# Altium schematic sheet MECHANICAL.SchDoc(MECHANICAL)
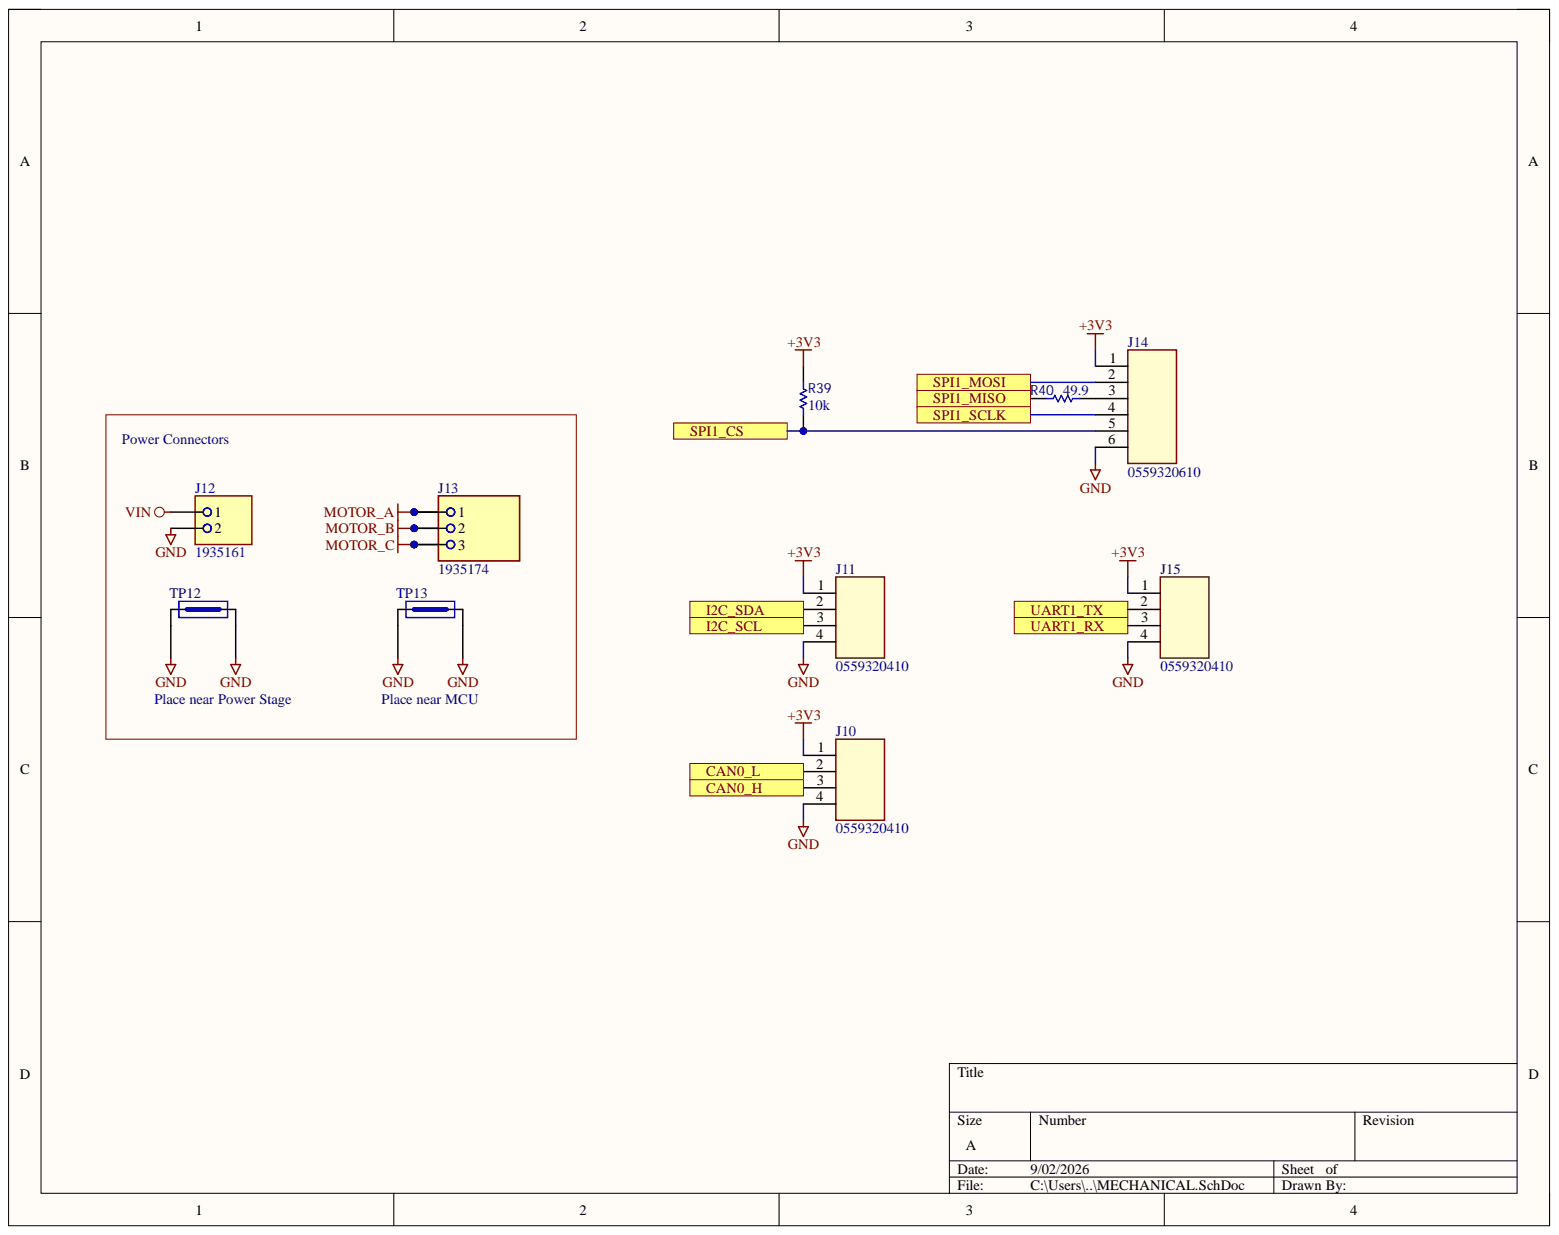
[diagram p0: MECHANICAL.SchDoc]

## Parts
J10  0559320410  [CONN_SD-55932-002_04_MOL]
J11  0559320410  [CONN_SD-55932-002_04_MOL]
J12  1935161  [PHOENIX_1935161]
J13  1935174  [PHOENIX_1935174]
J14  0559320610  [CONN_SD-55932-002_06_MOL]
J15  0559320410  [CONN_SD-55932-002_04_MOL]
R39  value 10k  [R-0402]  (hidden comment: Resistor 10k)
R40  value 49.9  [R-0402]  (hidden comment: Resistor 49.9)
TP12  D3082-05  [FP-D3082-05-MFG]  (value not drawn)
TP13  D3082-05  [FP-D3082-05-MFG]  (value not drawn)

## Ports
CAN0_H, CAN0_L, I2C_SCL, I2C_SDA, SPI1_CS, SPI1_MISO, SPI1_MOSI, SPI1_SCLK, UART1_RX, UART1_TX

## Nets
+3V3: J10.1 J11.1 J14.1 J15.1 R39.2
GND: J10.4 J11.4 J12.2 J14.6 J15.4 TP12.1 TP12.2 TP13.1 TP13.2
MOTOR_A: J13.1
MOTOR_B: J13.2
MOTOR_C: J13.3
VIN: J12.1
CAN0_H: J10.3 ; port CAN0_H
CAN0_L: J10.2 ; port CAN0_L
I2C_SCL: J11.3 ; port I2C_SCL
I2C_SDA: J11.2 ; port I2C_SDA
SPI1_CS: J14.5 R39.1 ; port SPI1_CS
SPI1_MISO: R40.1 ; port SPI1_MISO
SPI1_MOSI: J14.2 ; port SPI1_MOSI
SPI1_SCLK: J14.4 ; port SPI1_SCLK
UART1_RX: J15.3 ; port UART1_RX
UART1_TX: J15.2 ; port UART1_TX
NetJ14_3: J14.3 R40.2

## Library data of the parts
J10 J11 J15 = CMP-002-00336-1: 2.00mm Pitch MicroClasp Wire-to-Board Header, Single Row, Vertical, 4 Circuits, with PCB Locator; Manufacturer: Molex; Component Kind: Standard; ComponentLink1Description: Datasheet; ComponentLink1URL: https://www.molex.com/en-us/products/part-detail/559320410?display=pdf; Library Name: BLDC_Symbols.SchLib; Manufacturer PN: 0559320410; Package: TH; Supplier 1: Digikey; Supplier Part Number 1: WM9849-ND; Type: Header; Value: 0559320410
J12 = CMP-002-00334-1: PCB Terminal Screw 5mm 16AWG 400V 17.5A 2 Position COMBICON PT Series; Manufacturer: Phoenix Contact; Current: 18A; Voltage Rating: 300V; Component Kind: Standard; ComponentLink1Description: Datasheet; ComponentLink1URL: https://mm.digikey.com/Volume0/opasdata/d220001/medias/docus/909/1935161.pdf; Library Name: BLDC_Symbols.SchLib; Manufacturer PN: 1935161; Package: TH; Supplier 1: Digikey; Supplier Part Number 1: 277-1667-ND; Type: Screw Terminal; Value: 1935161
J13 = CMP-002-00333-1: PCB Terminal Screw 5mm 16AWG 400V 17.5A 3 Position COMBICON PT Series; Manufacturer: Phoenix Contact; Current: 18A; Voltage Rating: 300V; Component Kind: Standard; ComponentLink1Description: Datasheet; ComponentLink1URL: https://mm.digikey.com/Volume0/opasdata/d220001/medias/docus/1123/1935174.pdf; Library Name: BLDC_Symbols.SchLib; Manufacturer PN: 1935174; Package: TH; Supplier 1: Digikey; Supplier Part Number 1: 277-1578-ND; Type: Screw Terminal; Value: 1935174
J14 = CMP-002-00337-1: 2.00mm Pitch MicroClasp Wire-to-Board Header, Single Row, Vertical, 6 Circuits, with PCB Locator; Manufacturer: Molex; Component Kind: Standard; ComponentLink1Description: Datasheet; ComponentLink1URL: https://www.molex.com/en-us/products/part-detail/559320610?display=pdf; Library Name: BLDC_Symbols.SchLib; Manufacturer PN: 559320610; Package: TH; Supplier 1: Digikey; Supplier Part Number 1: WM12288-ND; Type: Header; Value: 0559320610
R39 = Resistor 10k: 10 kOhms ±5% 0.063W, 1/16W Chip Resistor 0402 (1005 Metric) Moisture Resistant Thick Film; Manufacturer: YAGEO; Manufacturer PN: RC0402JR-0710KL; Power: 0.0625; Component Kind: Standard; ComponentLink1Description: Datasheet; Library Name: BLDC_Symbols.SchLib; Package: 0402; Supplier 1: Digikey; Supplier Part Number 1: 311-10KJRCT-ND; Tolerance: 5%; Type: Resistor
R40 = Resistor 49.9: 49.9 Ohms ±1% 0.063W, 1/16W Chip Resistor 0402 (1005 Metric) Moisture Resistant Thick Film; Manufacturer: YAGEO; Manufacturer PN: RC0402FR-0749R9L; Power: 0.0625; Component Kind: Standard; ComponentLink1Description: Datasheet; Library Name: BLDC_Symbols.SchLib; Package: 0402; Supplier 1: Digikey; Supplier Part Number 1: 311-49.9LRCT-ND; Tolerance: 1%; Type: Resistor
TP12 TP13 = CMP-00030-00019-1: Harwin D3082-05 Throughboard Shorting Link 10.16mm Uninsulated; Component Kind: Standard; ComponentLink1Description: Datasheet; Library Name: BLDC_Symbols.SchLib; Manufacturer: Harwin; Manufacturer PN: D3082-05; Supplier 1: Digikey; Supplier Part Number 1: 952-1873-ND; Type: Measuring Point; Value: D3082-05
